# Altium schematic sheet WiFi Shield Schematic.SchDoc("WiFi Shield Schematic")
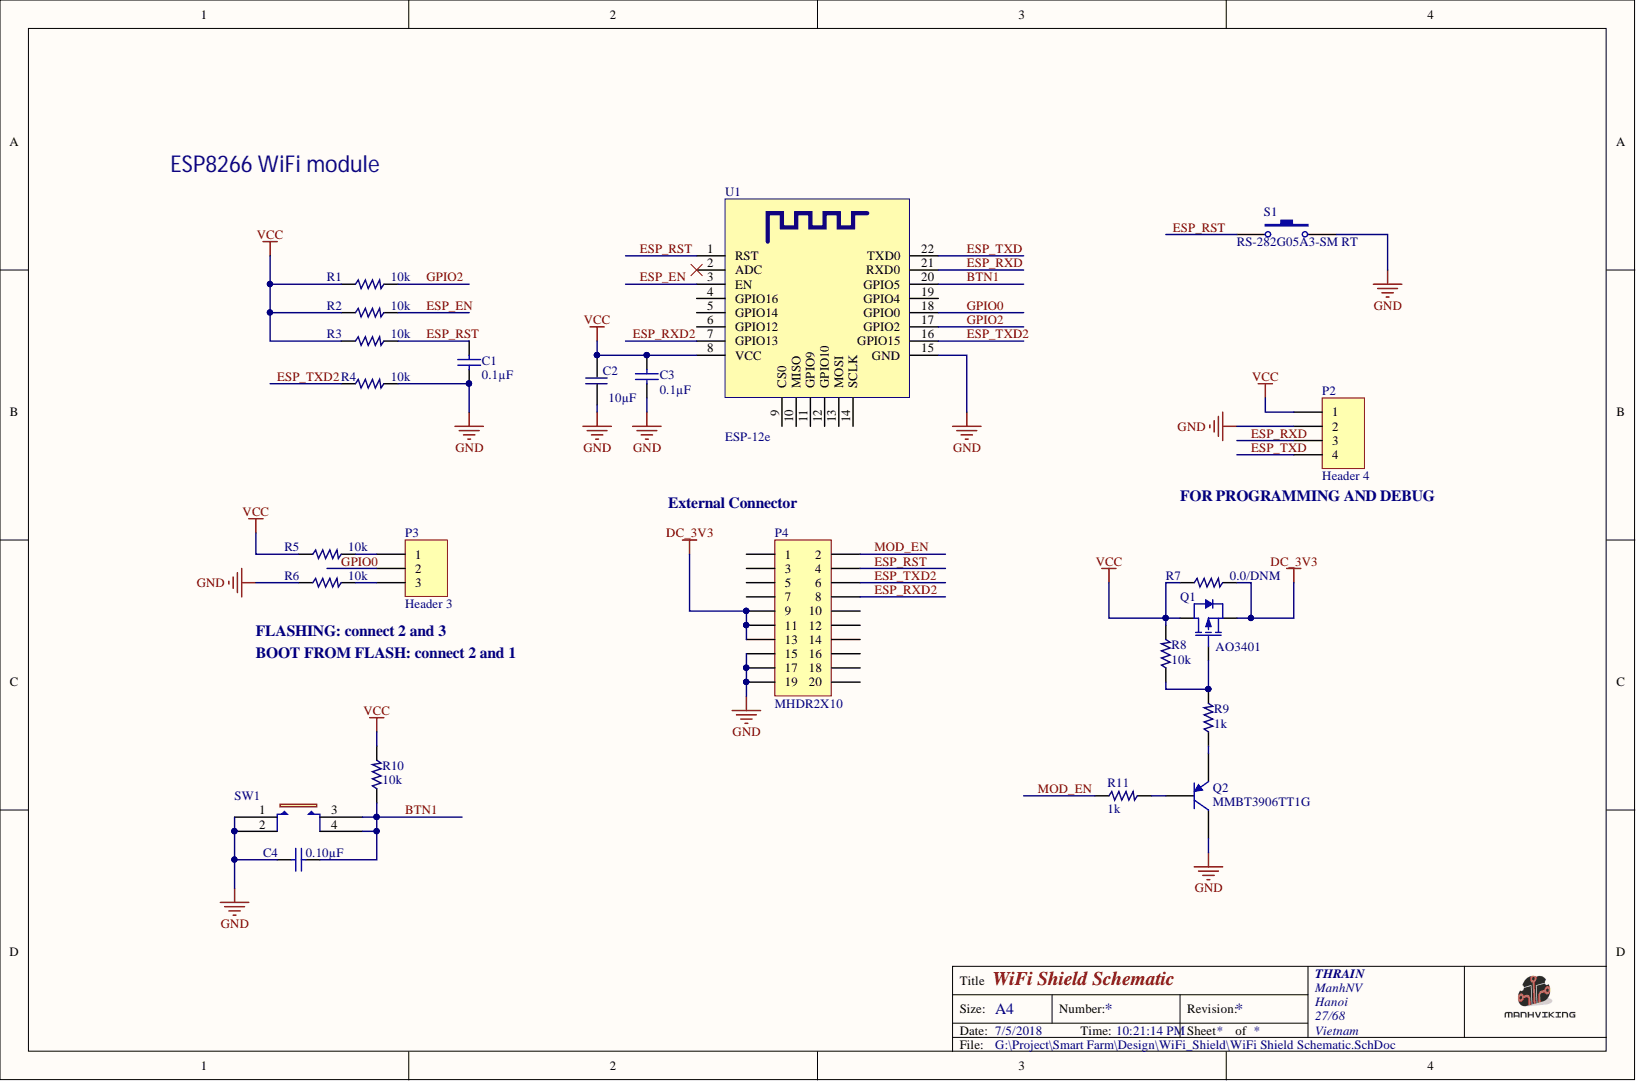
[diagram p0: WiFi Shield Schematic.SchDoc]

## Parts
C1  0.1µF  [C0402M]
C2  10µF  [C0603M]
C3  0.1µF  [C0402M]
C4  0.10µF  [C0402M]
P2  Header 4  [HDR1X4]
P3  Header 3  [HDR1X3]
P4  MHDR2X10  [MHDR2X10SMDM]
Q1  AO3401  [SOT95P240X120-3N]
Q2  MMBT3906TT1G  [SOT95P240X120-3N]
R1  10k  [R0402M]
R2  10k  [R0402M]
R3  10k  [R0402M]
R4  10k  [R0402M]
R5  10k  [R0402M]
R6  10k  [R0402M]
R7  0.0/DNM  [R0805M]
R8  10k  [R0402M]
R9  1k  [R0402M]
R10  10k  [R0402M]
R11  1k  [R0402M]
S1  RS-282G05A3-SM RT  [RS-282G05A3]
SW1  PTS645SL70SMTR92LFS  [PTS645SL70SMTR92LFS]  (value not drawn)
U1  ESP-12e  [ESP-12E]

## Nets
DC_3V3: P4.9 P4.11 P4.13 Q1.2 R7.1
GND: C1.2 C2.1 C3.2 C4.2 P2.2 P4.15 P4.17 P4.19 Q2.3 R4.1 R6.2 S1.2 SW1.1 SW1.2 U1.15(GND)
VCC: C2.2 C3.1 P2.1 Q1.3 R1.2 R2.2 R3.2 R5.2 R7.2 R8.1 R10.1 U1.8(VCC)
BTN1: C4.1 R10.2 SW1.3 SW1.4 U1.20(GPIO5)
ESP_EN: R2.1 U1.3(EN)
ESP_RST: C1.1 P4.4 R3.1 S1.1 U1.1(RST)
ESP_RXD: P2.3 U1.21(RXD0)
ESP_RXD2: P4.8 U1.7(GPIO13)
ESP_TXD: P2.4 U1.22(TXD0)
ESP_TXD2: P4.6 R4.2 U1.16(GPIO15)
GPIO0: P3.2 U1.18(GPIO0)
GPIO2: R1.1 U1.17(GPIO2)
MOD_EN: P4.2 R11.2
NetP3_1: P3.1 R5.1
NetP3_3: P3.3 R6.1
NetQ1_1: Q1.1 R8.2 R9.1
NetQ2_1: Q2.1 R11.1
NetQ2_2: Q2.2 R9.2

Not connected: P4 1 3 5 7 10 12 14 16 18 20; U1 2(ADC) 4(GPIO16) 5(GPIO14) 6(GPIO12) 9(CS0) 10(MISO) 11(GPIO9) 12(GPIO10) 13(MOSI) 14(SCLK) 19(GPIO4)

## Library data of the parts
C1 C3 = CAPN: Manufacturer Part Number: GRM155R61C104KA88J; Manufacturer: Murata Electronics North America; Voltage - Rated: 16V; Tolerance: ±10%; Temperature Coefficient: X5R; Notification: Current market demand for these product types have resulted in fluctuating and extending lead times. Lead times may differ.; Thickness (Max): 0.022" (0.55mm); Supplier Part Number 1: 490-6310-1-ND; Supplier 1: Digi-Key; Stock 1: 500000; Size / Dimension: 0.039" L x 0.020" W (1.00mm x 0.50mm); RoHS: RoHS Compliant; Ratings: -; Pricing 1: 1=0.1, 10=0.035, 100=0.0155, 500=0.01106, 1000=0.0087, 2500=0.00791, 5000=0.00727 (USD); Part Status: Active; Packaging: Cut Tape (CT); Package / Case: 0402 (1005 Metric); Operating Temperature: -55°C ~ 85°C; Mounting Type: Surface Mount, MLCC; Lead Spacing: -; Height - Seated (Max): -; Features: -; ComponentLink1URL: https://www.murata.com/~/media/webrenewal/support/library/catalog/products/capacitor/mlcc/c02e.ashx; ComponentLink1Description: https://www.murata.com/~/media/webrenewal/support/library/catalog/products/capacitor/mlcc/c02e.ashx; Component Kind: Standard; Category: Capacitors; Applications: General Purpose; Library Name: blueThermoSchlib.SchLib
C2 = CAPSMD: CAP CER 10UF 10V X5R 0603; Manufacturer Part Number: LMK107BBJ106MAHT; Manufacturer: Taiyo Yuden; Tolerance: ±20%; Voltage - Rated: 10V; Thickness (Max): 0.035" (0.90mm); Temperature Coefficient: X5R; Supplier Part Number 1: 587-3412-1-ND; Supplier 1: Digi-Key; Stock 1: 455125; Size / Dimension: 0.063" L x 0.032" W (1.60mm x 0.80mm); RoHS: RoHS Compliant; Ratings: AEC-Q200; Pricing 1: 1=0.44, 10=0.309, 100=0.1852, 500=0.1389, 1000=0.11729 (USD); Part Status: Active; Packaging: Cut Tape (CT); Package / Case: 0603 (1608 Metric); Operating Temperature: -55°C ~ 85°C; Mounting Type: Surface Mount, MLCC; Library Name: BLE.SchLib; Lead Style: -; Lead Spacing: -; Height - Seated (Max): -; Features: -; ComponentLink1URL: http://ds.yuden.co.jp/TYCOMPAS/ut/detail.do?productNo=LMK107BBJ106MAHT&fileName=LMK107BBJ106MAHT_SS&mode=specSheetDownload; ComponentLink1Description: http://ds.yuden.co.jp/TYCOMPAS/ut/detail.do?productNo=LMK107BBJ106MAHT&fileName=LMK107BBJ106MAHT_SS&mode=specSheetDownload; Component Kind: Standard; Category: Capacitors; Capacitance: 10µF; Applications: Automotive
C4 = CAPN: CAP CER 0.1UF 10V X5R 0402; Manufacturer Part Number: LMK105BJ104KV-F; Manufacturer: Taiyo Yuden; Tolerance: ±10%; Notification: Current market demand for these product types have resulted in fluctuating and extending lead times. Lead times may differ.; Module: IPCAM SoC; Category 2: Capacitors; Voltage - Rated: 10V; Thickness (Max): 0.022" (0.55mm); Temperature Coefficient: X5R; Supplier Part Number 2: 587-2835-1-ND; Supplier Part Number 1: 587-1227-1-ND; Supplier 2: Digi-Key; Supplier 1: Digi-Key; Stock 2: 17700; Stock 1: 12292406; Size / Dimension: 0.039" L x 0.020" W (1.00mm x 0.50mm); RoHS 2: RoHS Compliant; RoHS: RoHS Compliant; Ratings: -; Pricing 2: 1=0.1, 10=0.043, 100=0.019, 500=0.0136, 1000=0.01069, 2500=0.00972, 5000=0.00894 (USD); Pricing 1: 1=0.1, 10=0.025, 50=0.012, 100=0.009, 250=0.00652, 500=0.0048, 1000=0.00325, 5000=0.0025 (USD); Part Status: Active; Packaging: Cut Tape (CT); Package / Case: 0402 (1005 Metric); Operating Temperature: -55°C ~ 85°C; Mounting Type: Surface Mount, MLCC; Manufacturer Part Number 2: LMK105BJ104MV-F; Manufacturer 2: Taiyo Yuden; Lead Style: -; Lead Spacing: -; Height - Seated (Max): -; Features: -; Description 2: CAP CER 0.1UF 10V X5R 0402; ComponentLink2URL: http://ds.yuden.co.jp/TYCOMPAS/ut/detail.do?productNo=LMK105BJ104MV-F&fileName=LMK105BJ104MV-F_SS&mode=specSheetDownload; ComponentLink2Description: http://ds.yuden.co.jp/TYCOMPAS/ut/detail.do?productNo=LMK105BJ104MV-F&fileName=LMK105BJ104MV-F_SS&mode=specSheetDownload; ComponentLink1URL: http://ds.yuden.co.jp/TYCOMPAS/ut/detail.do?productNo=LMK105BJ104KV-F&fileName=LMK105BJ104KV-F_SS&mode=specSheetDownload; ComponentLink1Description: http://ds.yuden.co.jp/TYCOMPAS/ut/detail.do?productNo=LMK105BJ104KV-F&fileName=LMK105BJ104KV-F_SS&mode=specSheetDownload; Component Kind: Standard; Category: Capacitors; Capacitance: 0.10µF; Applications: General Purpose; Library Name: blueThermoSchlib.SchLib
P2 = Header 4: Header, 4-Pin; Signal Integrity: Connector; Library Name: Miscellaneous Connectors.IntLib; LatestRevisionNote: Re-released for DXP Platform.; LatestRevisionDate: 17-Jul-2002; Component Kind: Standard; Publisher: Altium Limited
P3 = Header 3: Header, 3-Pin; Signal Integrity: Connector; Library Name: Miscellaneous Connectors.IntLib; LatestRevisionNote: Re-released for DXP Platform.; LatestRevisionDate: 17-Jul-2002; Component Kind: Standard; Publisher: Altium Limited
P4 = MHDR2X10: Header, 10-Pin, Dual row; Signal Integrity: Connector; LatestRevisionNote: Re-released for DXP Platform.; LatestRevisionDate: 17-Jul-2002; Component Kind: Standard; Publisher: Altium Limited; Library Name: BinhLib.IntLib
Q1 = MOSFET-P1: P-Channel MOSFET; Component Kind: Standard; Library Name: BinhLib.IntLib
Q2 = PNP: TRANS PNP 40V 0.2A SC75-3; Manufacturer 1: ON Semiconductor; Vce Saturation (Max) @ Ib, Ic: 400mV @ 5mA, 50mA; Frequency - Transition: 250MHz; DC Current Gain (hFE) (Min) @ Ic, Vce: 100 @ 10mA, 1V; Category 1: Discrete Semiconductor Products; Transistor Type: PNP; Supplier Part Number 1: MMBT3906TT1GOSCT-ND; Supplier Device Package: SC-75, SOT-416; Supplier 1: Digi-Key; Stock 1: 508626; Simulation: PNP; Signal Integrity: PNP; RoHS 1: RoHS Compliant; Published: 8-Jun-2000; Pricing 1: 1=0.15, 10=0.133, 100=0.0727, 500=0.04472, 1000=0.03049 (USD); Part Status: Active; Packaging: Cut Tape (CT); PackageVersion: Aug-1999; PackageReference: SOT-23B; PackageDescription: 3-Pin SOT-23 Package 0.95 mm Pitch, 2.4 mm Lead Span; Package / Case: SC-75, SOT-416; Operating Temperature: -55°C ~ 150°C (TJ); Mounting Type: Surface Mount; Manufacturer Part Number 1: MMBT3906TT1G; Library Name: CarrierBoard.SchLib; LatestRevisionNote: IPC-7351 Footprint Added.; LatestRevisionDate: 29-May-2009; Description 1: TRANS PNP 40V 0.2A SC75-3; Current - Collector Cutoff (Max): -; ComponentLink1URL: http://www.onsemi.com/pub/Collateral/MMBT3906TT1-D.PDF; ComponentLink1Description: http://www.onsemi.com/pub/Collateral/MMBT3906TT1-D.PDF; Component Kind: Standard; Base Part Number: MMBT3906; Publisher: Altium Limited
R1-R6 R8 = RES_SMD: RES SMD 10K OHM 1% 1/16W 0402; Manufacturer Part Number: CRCW040210K0FKEDC; Manufacturer: Vishay Dale; Temperature Coefficient: ±100ppm/°C; Power (Watts): 0.063W, 1/16W; Tolerance: ±1%; Supplier Part Number 1: 541-3959-1-ND; Supplier Device Package: 0402; Supplier 1: Digi-Key; Stock 1: 336311; Size / Dimension: 0.039" L x 0.020" W (1.00mm x 0.50mm); RoHS: RoHS Compliant; Pricing 1: 1=0.1, 10=0.026, 100=0.0104, 1000=0.00466, 2500=0.00405, 5000=0.00334 (USD); Part Status: Active; Packaging: Cut Tape (CT); Package / Case: 0402 (1005 Metric); Operating Temperature: -55°C ~ 155°C; Library Name: BLE.SchLib; Height - Seated (Max): 0.014" (0.35mm); Features: -; Failure Rate: -; Composition: Thick Film; ComponentLink1URL: http://www.vishay.com/docs/28773/crcwce3.pdf; ComponentLink1Description: http://www.vishay.com/docs/28773/crcwce3.pdf; Component Kind: Standard; Category: Resistors
R7 = RES_SMD: Library Name: BLE.SchLib; Component Kind: Standard
R9 R11 = RES_SMD: RES SMD 1K OHM 1% 1/16W 0402; Manufacturer Part Number: RC0402FR-071KL; Manufacturer: Yageo; Temperature Coefficient: ±100ppm/°C; Power (Watts): 0.063W, 1/16W; Tolerance: ±1%; Supplier Part Number 1: 311-1.00KLRCT-ND; Supplier Device Package: 0402; Supplier 1: Digi-Key; Stock 1: 34605687; Size / Dimension: 0.039" L x 0.020" W (1.00mm x 0.50mm); RoHS: RoHS Compliant; Pricing 1: 1=0.1, 10=0.014, 100=0.0056, 1000=0.00253, 2500=0.0022, 5000=0.00181 (USD); Part Status: Active; Packaging: Cut Tape (CT); Package / Case: 0402 (1005 Metric); Operating Temperature: -55°C ~ 155°C; Library Name: BLE1.SchLib; Height - Seated (Max): 0.016" (0.40mm); Features: Moisture Resistant; Failure Rate: -; Composition: Thick Film; ComponentLink1URL: http://www.yageo.com/documents/recent/PYu-RC_Group_51_RoHS_L_8.pdf; ComponentLink1Description: http://www.yageo.com/documents/recent/PYu-RC_Group_51_RoHS_L_8.pdf; Component Kind: Standard; Category: Resistors
R10 = RES_SMD: RES SMD 10K OHM 1% 1/16W 0402; Manufacturer Part Number 1: AT0402FRE0710KL; Manufacturer 1: Yageo; Temperature Coefficient: ±50ppm/°C; Power (Watts): 0.063W, 1/16W; Category 1: Resistors; Tolerance: ±1%; Supplier Part Number 1: YAG2104CT-ND; Supplier Device Package: 0402; Supplier 1: Digi-Key; Stock 1: 128581; Size / Dimension: 0.039" L x 0.020" W (1.00mm x 0.50mm); RoHS 1: RoHS Compliant; Pricing 1: 1=0.15, 10=0.125, 100=0.049, 1000=0.02051, 2500=0.0188, 5000=0.01709 (USD); Part Status: Active; Packaging: Cut Tape (CT); Package / Case: 0402 (1005 Metric); Operating Temperature: -55°C ~ 155°C; Library Name: BLE.SchLib; Height - Seated (Max): 0.014" (0.35mm); Features: Anti-Sulfur, Automotive AEC-Q200, Moisture Resistant; Failure Rate: -; Description 1: RES SMD 10K OHM 1% 1/16W 0402; Composition: Thin Film; ComponentLink1URL: http://www.yageo.com/documents/recent/PYu-AT_51_RoHS_L_5.pdf; ComponentLink1Description: http://www.yageo.com/documents/recent/PYu-AT_51_RoHS_L_5.pdf; Component Kind: Standard
S1 = SW-PB: SWITCH TACTILE SPST-NO 0.05A 12V; Manufacturer: C&K; Switch Travel: 0.25mm; Switch Function: Off-Mom; Outline: 6.00mm x 3.60mm; Operating Force: 250gf; Mechanical Life: 50,000 Cycles; Illumination: Non-Illuminated; Contact Rating @ Voltage: 0.05A @ 12VDC; Actuator Type: Rectangular Button; Actuator Orientation: Top Actuated; Actuator Material: Polyamide (PA), Nylon; Actuator Height off PCB, Vertical: 2.50mm; Termination Style: Gull Wing; Supplier Part Number 1: CKN10384CT-ND; Supplier 1: Digi-Key; Stock 1: 55078; RoHS: RoHS Compliant; Pricing 1: 1=0.81, 10=0.78, 25=0.7356, 50=0.6474, 100=0.5885, 250=0.55908, 500=0.54436, 1000=0.42666 (USD); Part Status: Active; Packaging: Cut Tape (CT); Operating Temperature: -; Mounting Type: Surface Mount; Manufacturer Part Number: RS-282G05A3-SM RT; Library Name: Miscellaneous Devices.IntLib; Ingress Protection: -; Illumination Voltage (Nominal): -; Illumination Type, Color: -; Features: -; ComponentLink1URL: https://media.digikey.com/pdf/Data%20Sheets/C&K/RS-282G05A-SM_RT.pdf; ComponentLink1Description: https://media.digikey.com/pdf/Data%20Sheets/C&K/RS-282G05A-SM_RT.pdf; Component Kind: Standard; Circuit: SPST-NO; Category: Switches; Actuator Length, Right Angle: -
SW1 = A08-0790: SWITCH TACTILE SPST-NO 0.05A 12V; Manufacturer 1: C&K; Switch Function: Off-Mom; Outline: 6.00mm x 6.00mm; Operating Force: 130gf; Mechanical Life: 100,000 Cycles; Illumination: Non-Illuminated; Database Table Name: AltiumComponents; Contact Rating @ Voltage: 0.05A @ 12VDC; Category 1: Switches; Actuator Type: Standard; Actuator Orientation: Top Actuated; Actuator Material: Polyamide (PA66), Nylon 6/6; Actuator Height off PCB, Vertical: 7.00mm; Termination Style: Gull Wing; Switch Travel: -; Supplier Part Number 1: CKN10820CT-ND; Supplier 1: Digi-Key; Stock 1: 9940; RoHS 1: RoHS Compliant; Pricing 1: 1=0.29, 10=0.28, 25=0.2704, 50=0.2606, 100=0.2461, 250=0.23164 (USD); Part Status: Active; Packaging: Cut Tape (CT); Operating Temperature: -20°C ~ 60°C; Mounting Type: Surface Mount; Manufacturer Part Number 1: PTS645SL70SMTR92LFS; Library Name: AltiumDbLib.DbLib; Ingress Protection: -; Illumination Voltage (Nominal): -; Illumination Type, Color: -; Features: -; Description 1: SWITCH TACTILE SPST-NO 0.05A 12V; ComponentLink1URL: http://www.ckswitches.com/media/1471/pts645.pdf; ComponentLink1Description: http://www.ckswitches.com/media/1471/pts645.pdf; Component Kind: Standard; Circuit: SPST-NO; Actuator Length, Right Angle: -
U1 = ESP-12e: ESP8266 SMT MODULE; Manufacturer Part Number: 2491; Manufacturer: Adafruit Industries LLC; Voltage - Supply: 3 V ~ 3.6 V; Serial Interfaces: I²C, I²S, SPI, UART; Sensitivity: -98dBm; RF Family/Standard: WiFi; Protocol: 802.11b/g/n; Power - Output: 20.5dBm; Frequency: 2.4GHz; Data Rate: 72.2Mbps; Current - Transmitting: 120mA ~ 170mA; Current - Receiving: 50mA ~ 56mA; Antenna Type: Integrated, Trace; Utilized IC / Part: ESP8266; Supplier Part Number 1: 1528-1438-ND; Supplier 1: Digi-Key; Stock 1: 493; RoHS: RoHS Compliant; Pricing 1: 1=6.95 (USD); Part Status: Obsolete; Packaging: Bulk; Package / Case: Module; Operating Temperature: -40°C ~ 125°C; Mounting Type: Surface Mount; Modulation: -; Memory Size: -; Library Name: BLE.SchLib; ComponentLink1URL: https://www.adafruit.com/images/product-files/2471/0A-ESP8266__Datasheet__EN_v4.3.pdf; ComponentLink1Description: https://www.adafruit.com/images/product-files/2471/0A-ESP8266__Datasheet__EN_v4.3.pdf; Component Kind: Standard; Category: RF/IF and RFID
